# Altium schematic sheet 4. ADC.SchDoc("4. ADC")
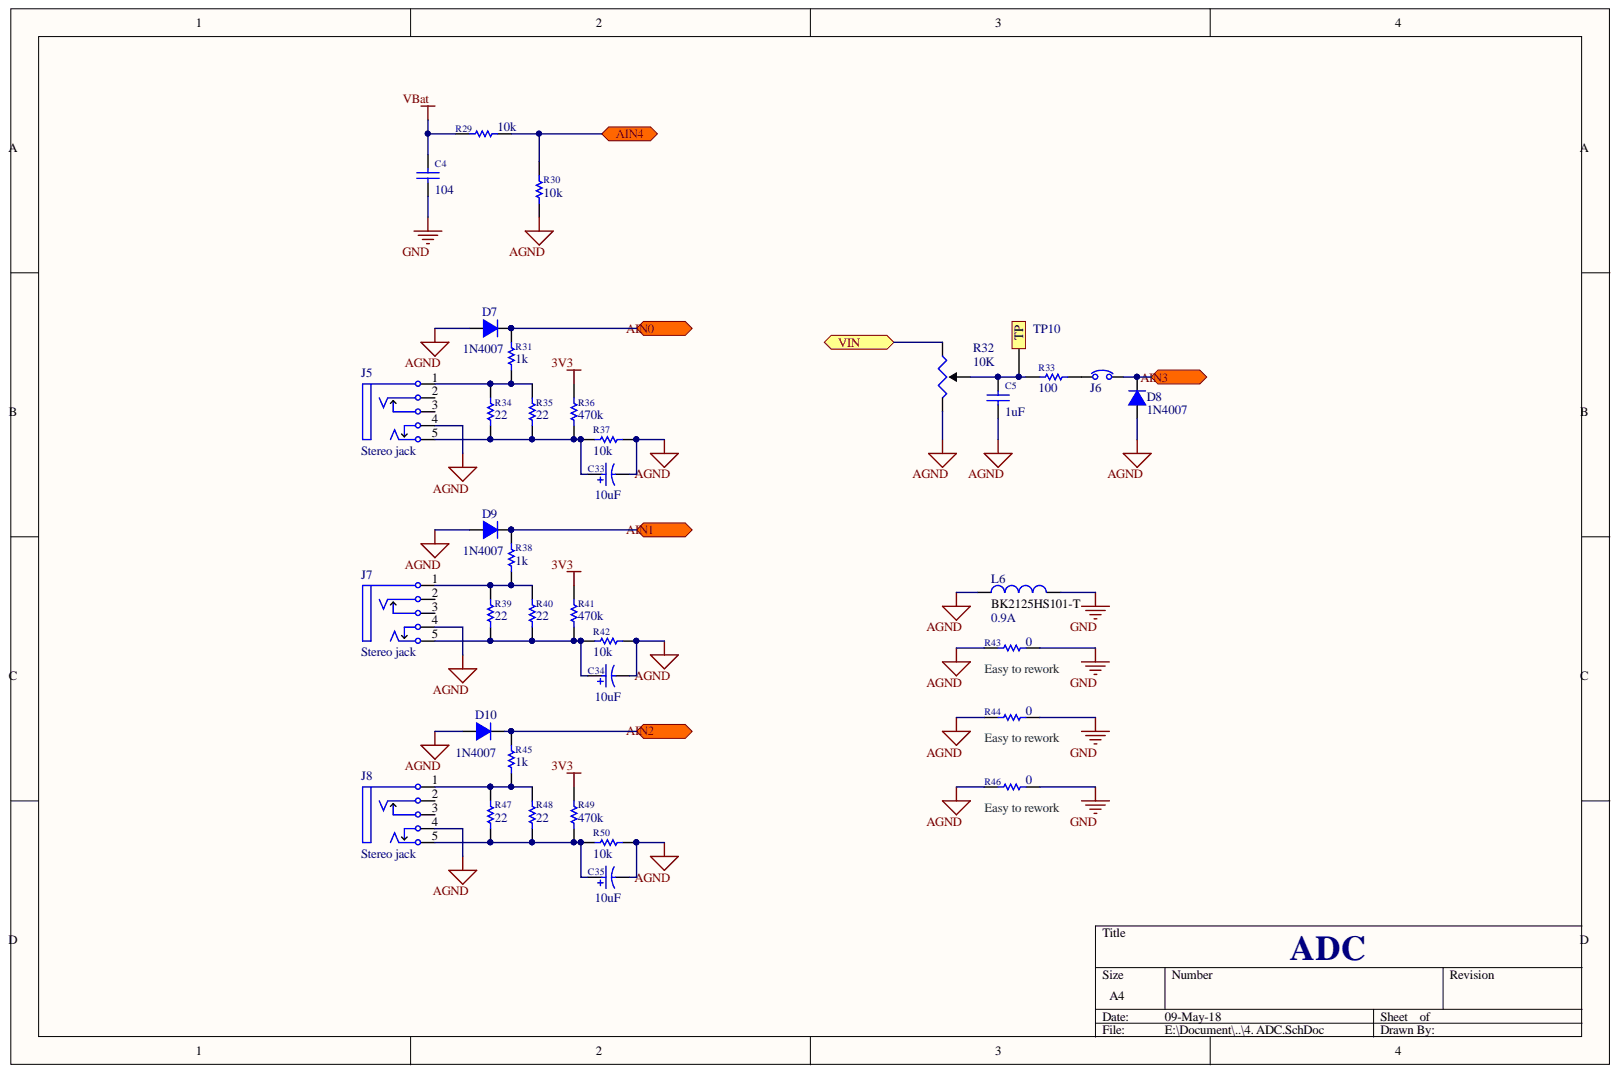
[diagram p0: 4. ADC.SchDoc]

## Parts
C4  104  [sm-0603-c]
C5  value 1uF  [sm-0603-c]  (hidden comment: 104)
C33  10u  value 10uF  [Cap SMD Tant 10u]
C34  10u  value 10uF  [Cap SMD Tant 10u]
C35  10u  value 10uF  [Cap SMD Tant 10u]
D7  1N4007  [SOD-123]
D8  1N4007  [SOD-123]
D9  1N4007  [SOD-123]
D10  1N4007  [SOD-123]
J5  Stereo jack  [Audio Stereo Jack]
J6  jumper selector  [CON.HDR1X2]  (value not drawn)
J7  Stereo jack  [Audio Stereo Jack]
J8  Stereo jack  [Audio Stereo Jack]
L6  BK2125HS101-T  Current: 0.9A  [L2012-0805]
R29  10k  [SM-0603-R]
R30  10k  [SM-0603-R]
R31  value 1k  [SM-0603-R]  (hidden comment: 10k)
R32  value 10K  [TRIM Rect]  (hidden comment: Trimmer)
R33  value 100  [SM-0603-R]  (hidden comment: 470k)
R34  value 22  [SM-RES-1206]  (hidden comment: 10k)
R35  22  [SM-0603-R]
R36  10k  value 470k  [SM-0603-R]
R37  470k  value 10k  Part: 10k  [SM-0603-R]
R38  value 1k  [SM-0603-R]  (hidden comment: 10k)
R39  value 22  [SM-RES-1206]  (hidden comment: 10k)
R40  22  [SM-0603-R]
R41  10k  value 470k  [SM-0603-R]
R42  470k  value 10k  Part: 10k  [SM-0603-R]
R43  0  [R2012-0805]
R44  0  [R2012-0805]
R45  value 1k  [SM-0603-R]  (hidden comment: 10k)
R46  0  [R2012-0805]
R47  value 22  [SM-RES-1206]  (hidden comment: 10k)
R48  22  [SM-0603-R]
R49  10k  value 470k  [SM-0603-R]
R50  470k  value 10k  Part: 10k  [SM-0603-R]
TP10  4V3_PG  [TP]  (value not drawn)  (no BOM)

## Ports
AIN0, AIN1, AIN2, AIN3, AIN4, VIN

## Nets
3V3: R36.2 R41.2 R49.2
AGND: C5.2 C33.2 C34.2 C35.2 D7.1 D8.1 D9.1 D10.1 J5.4 J7.4 J8.4 L6.1 R30.1 R32.3 R37.1 R42.1 R43.1 R44.1 R46.1 R50.1
GND: C4.2 L6.2 R43.2 R44.2 R46.2
VBAT: C4.1 R29.1
AIN0: D7.2 R31.2 ; port AIN0
AIN1: D9.2 R38.2 ; port AIN1
AIN2: D10.2 R45.2 ; port AIN2
AIN3: D8.2 J6.2 ; port AIN3
AIN4: R29.2 R30.2 ; port AIN4
VIN: R32.1 ; port VIN
NetC5_1: C5.1 R32.2 R33.2 TP10.1
NetC33_1: C33.1 J5.5 R34.1 R35.1 R36.1 R37.2
NetC34_1: C34.1 J7.5 R39.1 R40.1 R41.1 R42.2
NetC35_1: C35.1 J8.5 R47.1 R48.1 R49.1 R50.2
NetJ5_1: J5.1 R31.1 R34.2 R35.2
NetJ6_1: J6.1 R33.1
NetJ7_1: J7.1 R38.1 R39.2 R40.2
NetJ8_1: J8.1 R45.1 R47.2 R48.2

Not connected: J5 2 3; J7 2 3; J8 2 3

## Library data of the parts
C4 C5 = Capacitor: CAP CER 10000PF 16V 0603; Manufacturer: Taiyo Yuden; Manufacturer P/N: EMK107SD103JA-T; Vendor: DIGIKEY; Vendor P/N: 587-1093-1-ND; Component Kind: Standard; Library Name: My_Integrated_Library.IntLib; Package: 0603; Part: EMK107SD103JA-T; PCB3D: PolySwitch2; Voltage: 16V
  C4: Value: 104
C33-C35 = Cap_Pol: CAP CER 10UF 6.3V X5R 1206; Manufacturer: Samsung Electro-Mechanics; Manufacturer P/N: CL31A106MQHNNNE; Tollerance: 20%; Vendor: DIGIKEY; Vendor P/N: 1276-3045-1-ND; Component Kind: Standard; Library Name: My_Integrated_Library.IntLib; Package: 1206; Part: 10u; Voltage: 6.3V
D7-D10 = Diode 1N4007: DIODE 100V/1A; Manufacturer: TOSHIBA; Manufacturer P/N: 1N4007-M7; Current: 1A; Voltage: 100V; Component Kind: Standard; Library Name: My_Integrated_Library.IntLib; Package: DO-214AC; Part: Diode 1N4007; Price: 500 VND; Value: 1N4007; Vendor: www.tme.vn; Vendor P/N: 1N4007-M7
J5 J7 J8 = Audio Jack: Stereo audio jack; Component Kind: Standard; Library Name: SangNgocLe.IntLib
L6 = Inductor: FERRITE BEAD 100 OHM 0805 1LN; Manufacturer: Taiyo Yuden; Res: 100 OHM; Vendor: DIGIKEY; Vendor P/N: 587-1903-1-ND; Component Kind: Standard; Library Name: My_Integrated_Library.IntLib; Manufacturer P/N: BK2125HS101-T; Package: 0805; Part: BK2125HS101-T; Value: BK2125HS101-T
R29-R31 R33-R42 R45 R47-R50 = Resistor: RES SMD 10K OHM 5% 1/10W 0603; Manufacturer: Panasonic Electronic Components; Manufacturer P/N: ERJ-3GEYJ103V; Vendor: DIGIKEY; Vendor P/N: P10KGCT-ND; Component Kind: Standard; Library Name: My_Integrated_Library.IntLib; Package: 0603; Power: 1/10W; Tollerance: 5%
  R29 R30: Part: 10k; Value: 10k
  R31 R33 R34 R36 R38 R39 R41 R45 R47 R49: Part: 10k
  R35 R40 R48: Part: 10k; Value: 22
R32 = Trimmer: Res var, Rectangle Trimmer; Vendor: Nhat Tao - IC Thuan; Component Kind: Standard; ComponentLink1Description: Digikey Part Link; ComponentLink2Description: Open Datasheet; Date of Price: 19/11/2010; LatestRevisionDate: 04-Mar-2005; LatestRevisionNote: PCB Footprint replaced.; Library Name: My_Integrated_Library.IntLib; PackageReference: J1-0603; Part: Trimmer; Price: 2000 VND; Published: 8-Jun-2000; ComponentLink2URL: H:\LIBRARY\LIBRARY.Datasheet\
R43 R44 R46 = Resistor: RES SMD 0 OHM JUMPER 1/10W 0805; Manufacturer: Panasonic Electronic Components; Manufacturer P/N: ERJ-6GEY0R00V; Tollerance: 5%; Vendor: DIGIKEY; Vendor P/N: P0.0ACT-ND; Component Kind: Standard; Library Name: My_Integrated_Library.IntLib; Package: 0805; Part: 0; Power: 1/10W; Value: 0
TP10 = TP: Test Point; Library Name: My_Integrated_Library.IntLib
J6 = jumper selector: Component Kind: Standard; ComponentLink2Description: Open Datasheet; Date of Price: 19/11/2010; Library Name: My_Integrated_Library.IntLib; Part: jumper selector; Price: 500 VND
